# Altium schematic sheet DVK-AXM0F243-915-x-ANT-GEVB.SchDoc
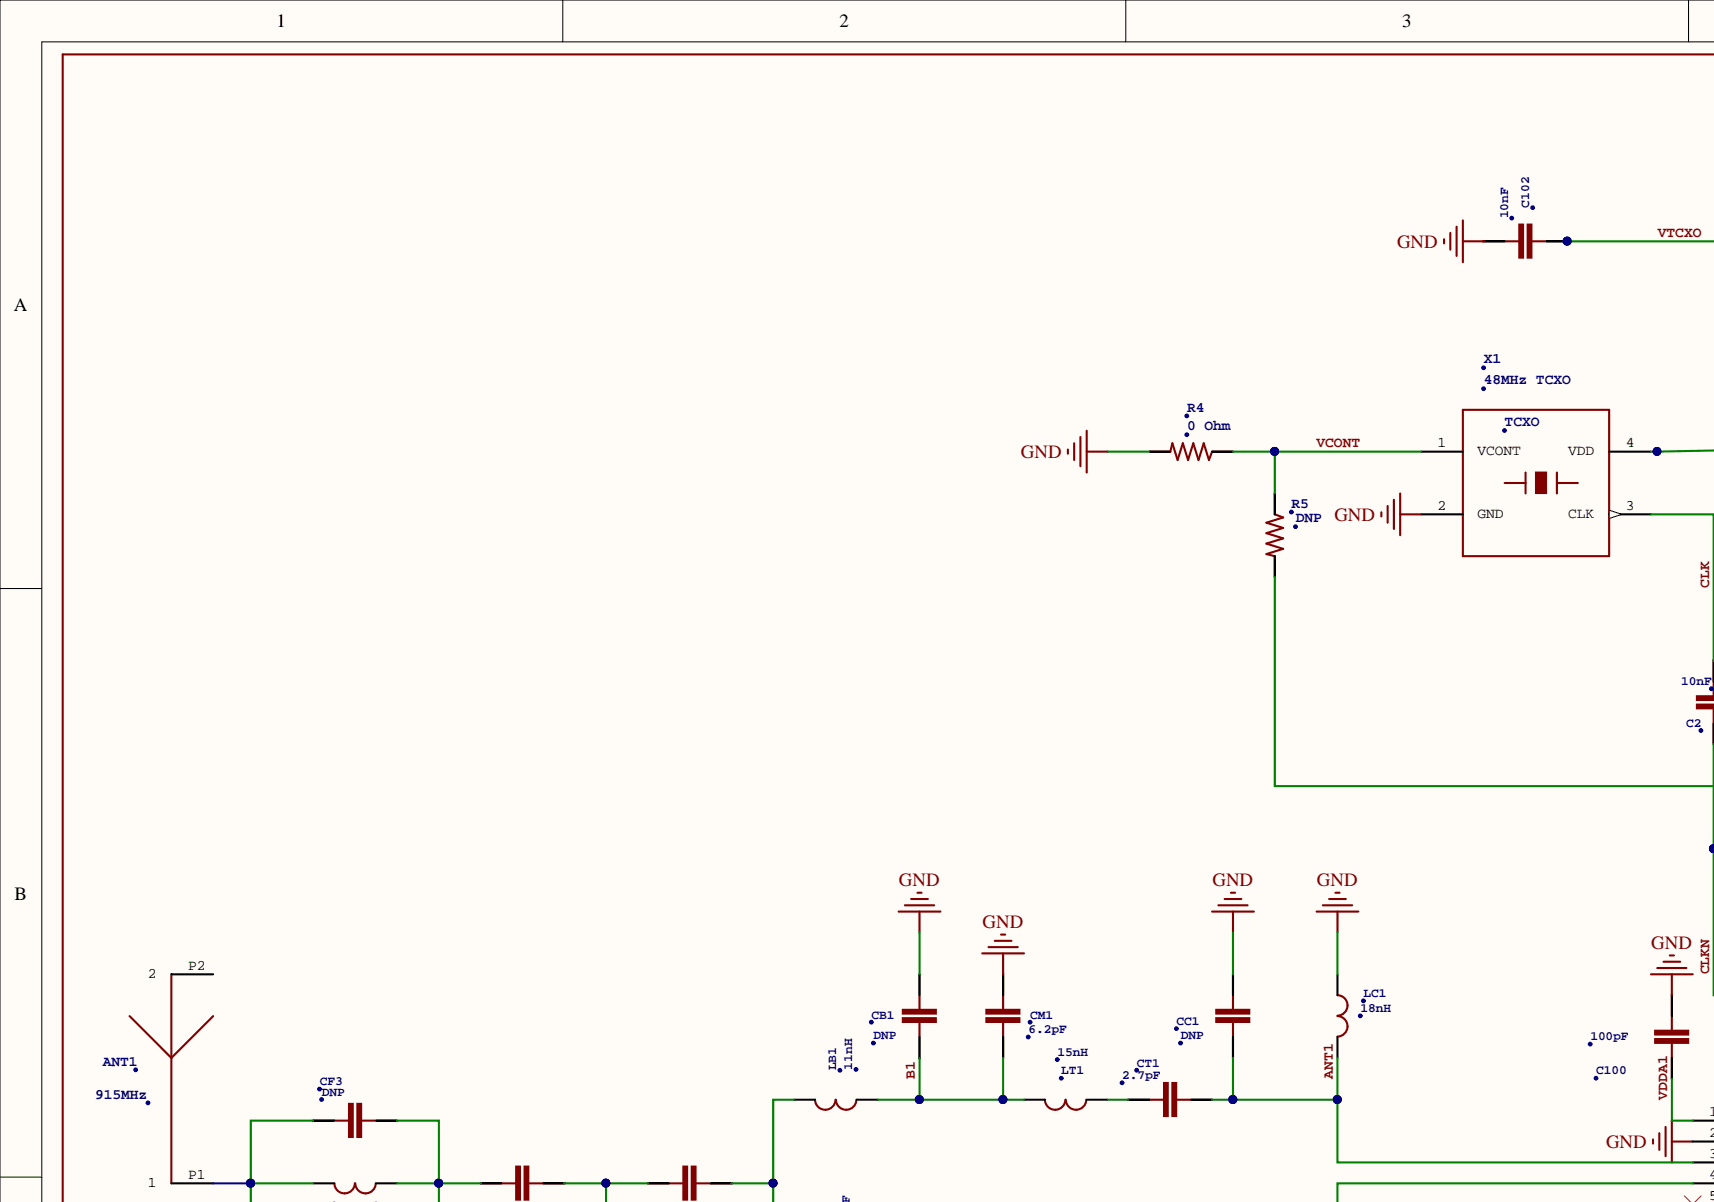
[diagram p0: DVK-AXM0F243-915-x-ANT-GEVB.SchDoc, top left]
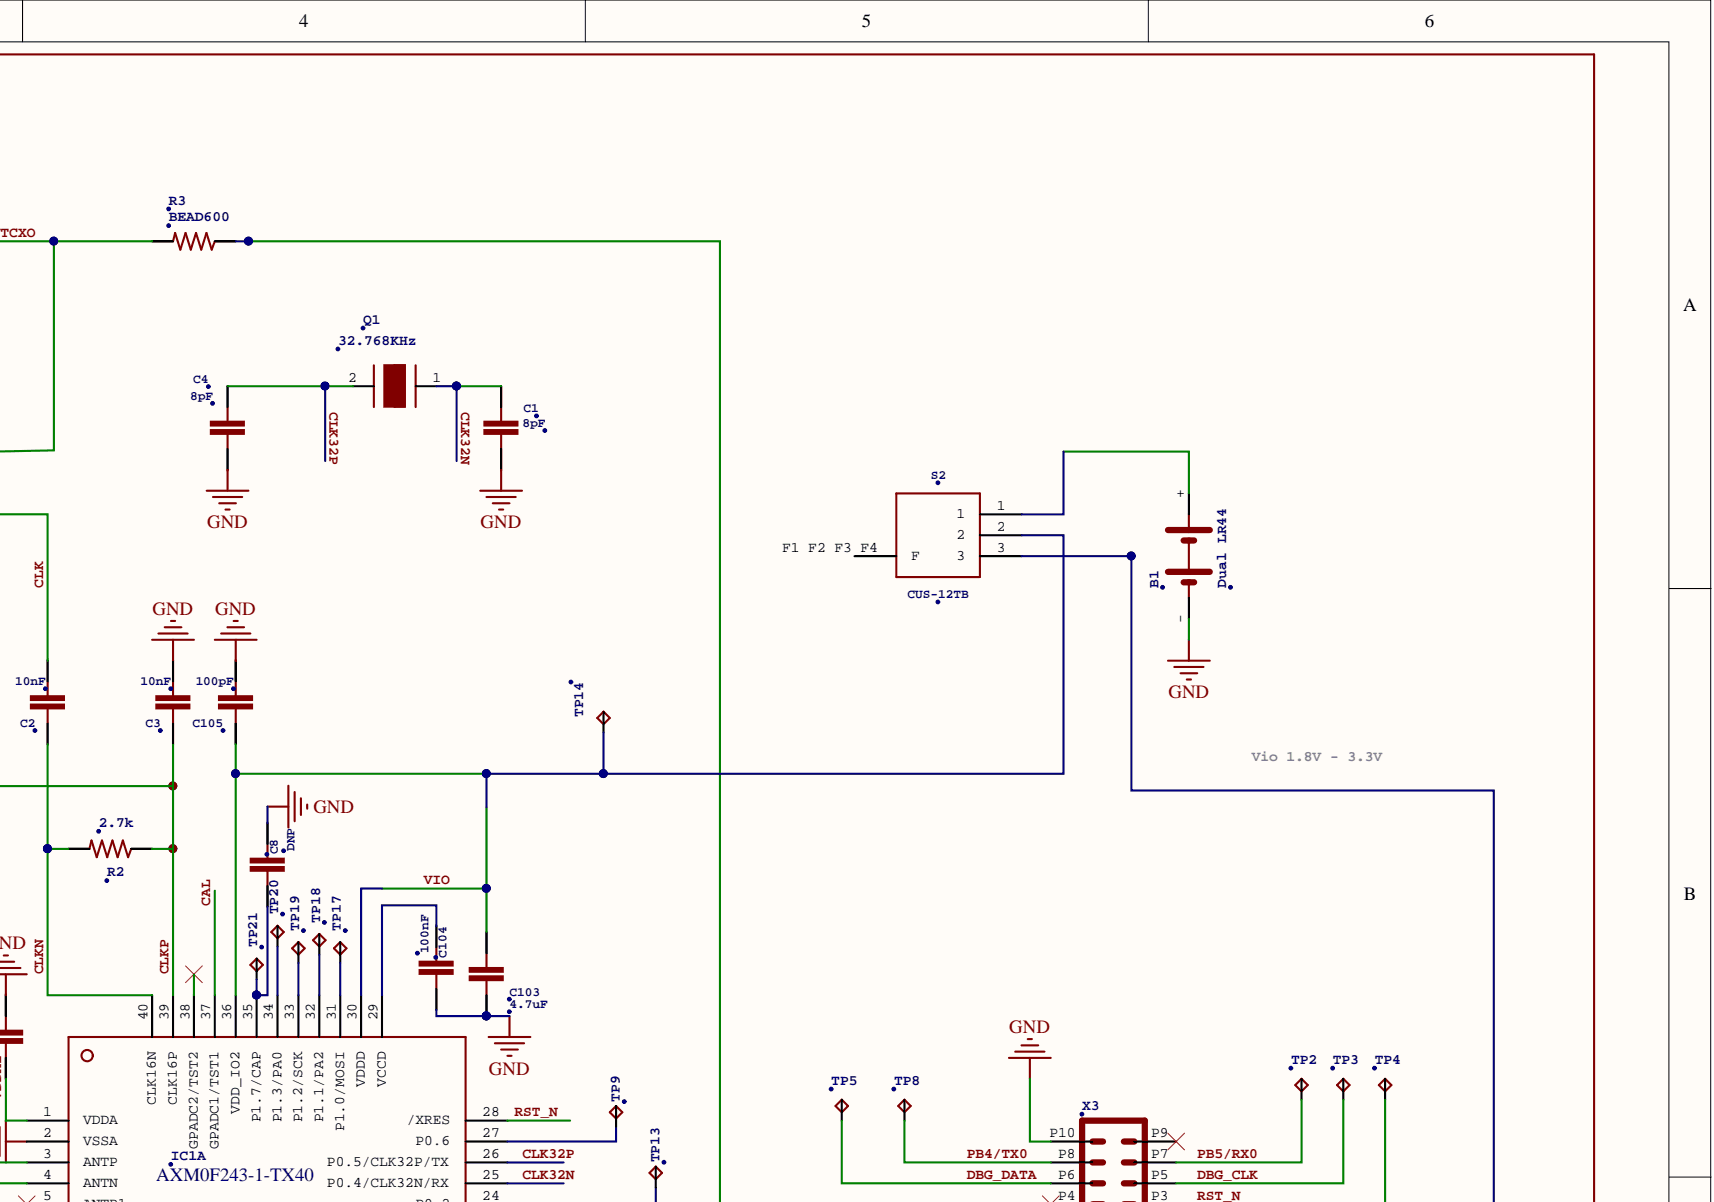
[diagram p1: DVK-AXM0F243-915-x-ANT-GEVB.SchDoc, top right]
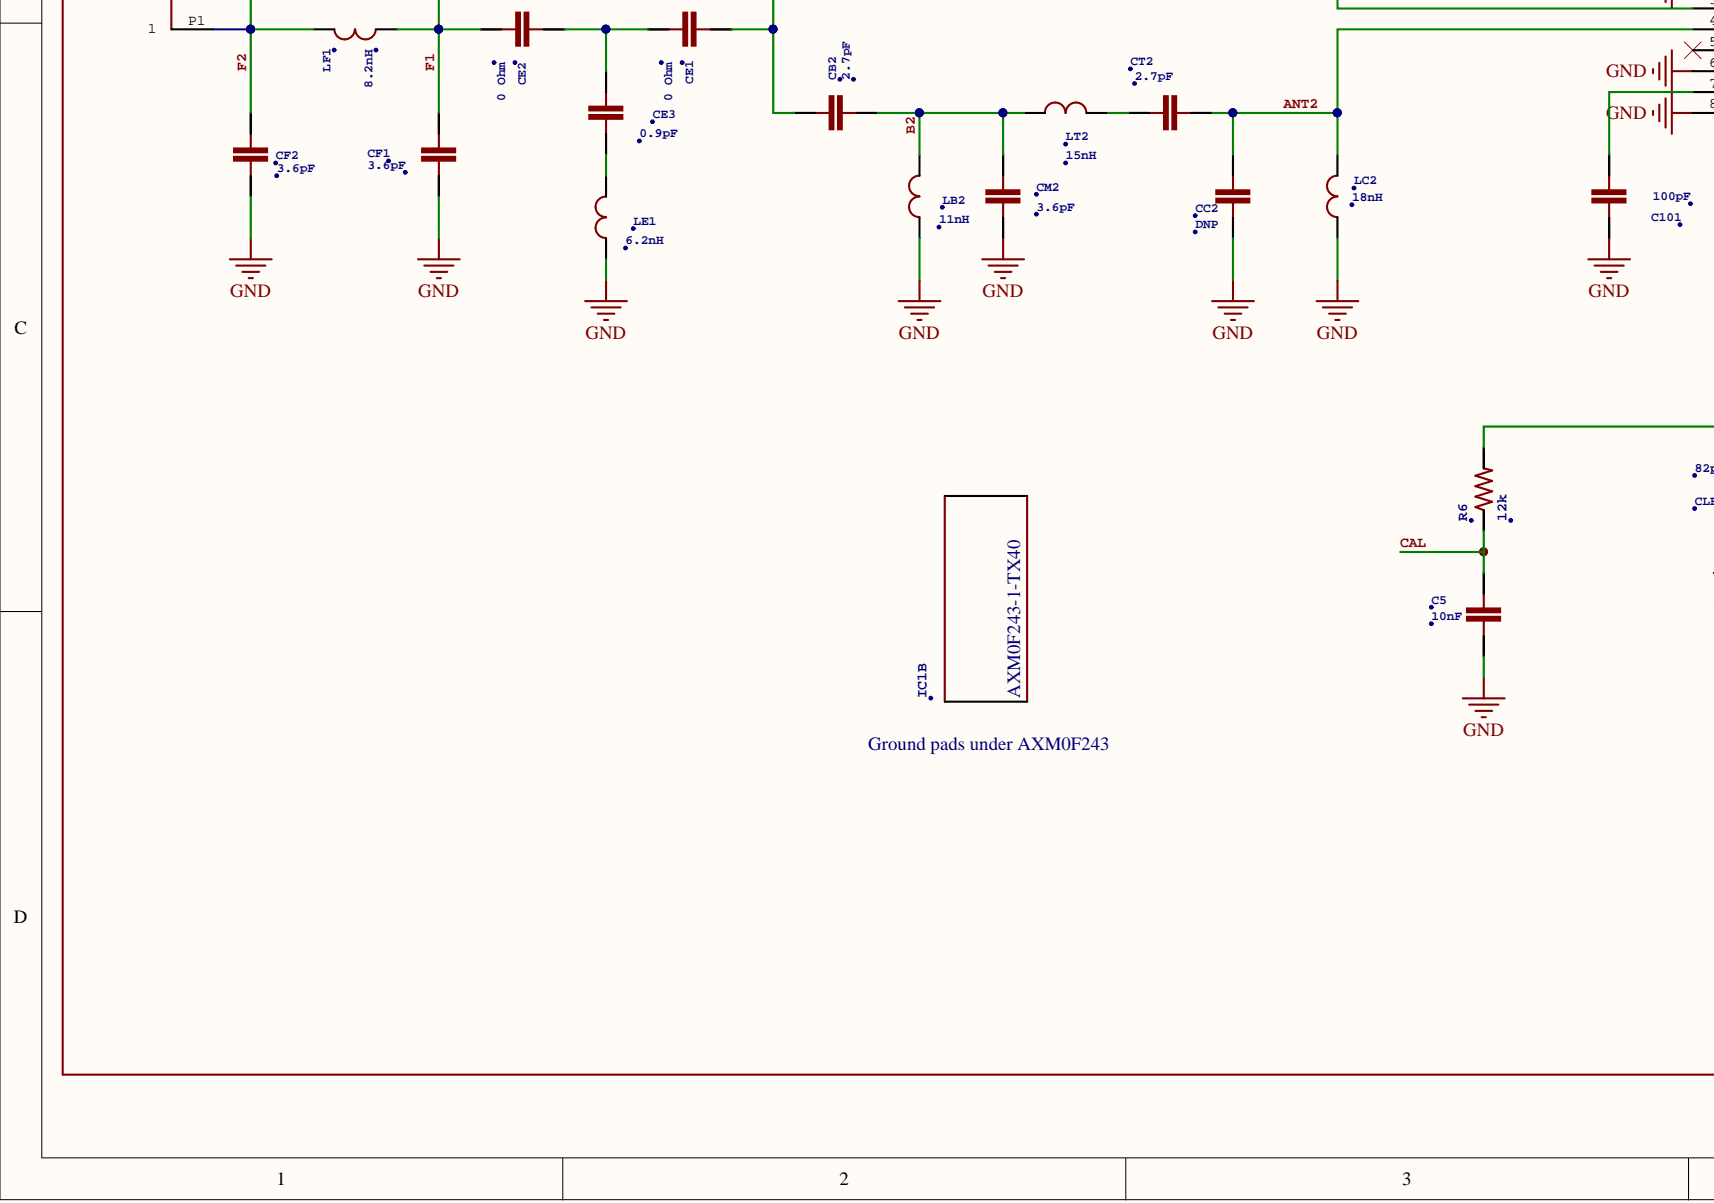
[diagram p2: DVK-AXM0F243-915-x-ANT-GEVB.SchDoc, bottom left]
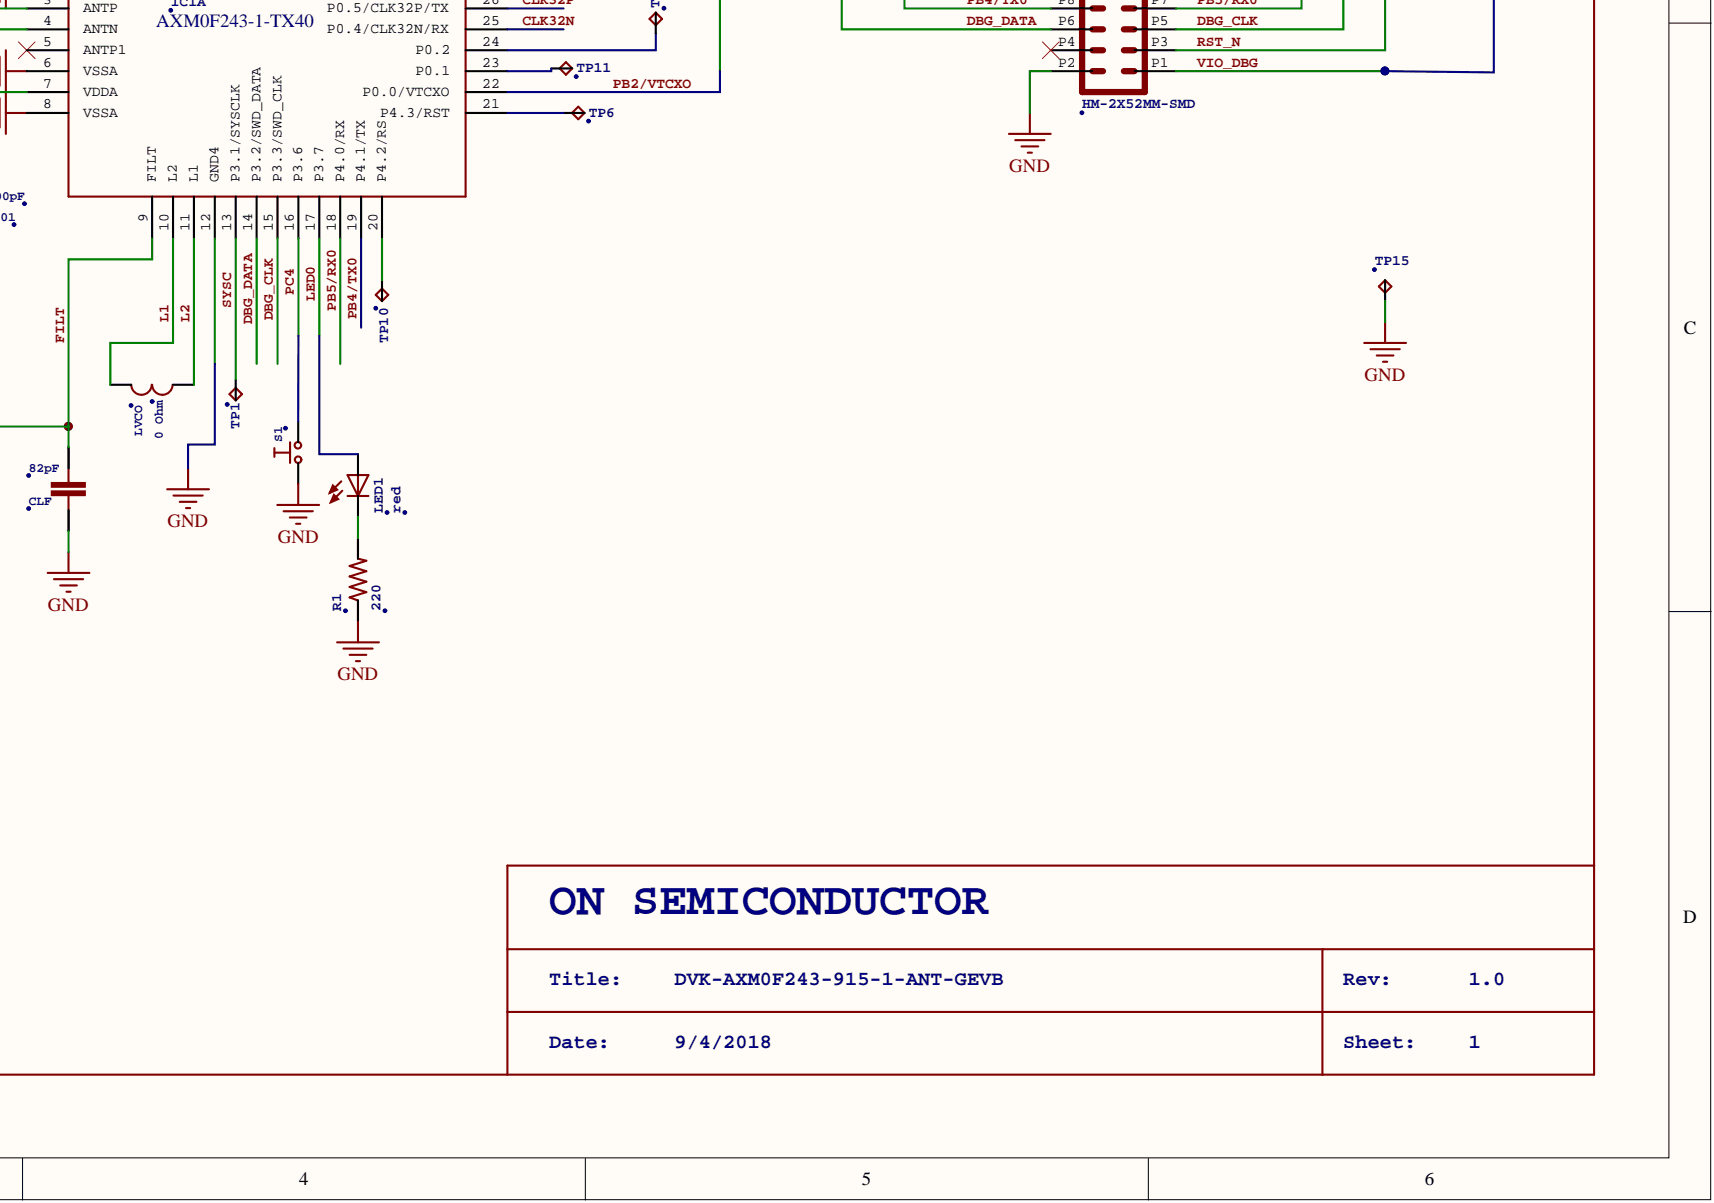
[diagram p3: DVK-AXM0F243-915-x-ANT-GEVB.SchDoc, bottom right]

## Parts
ANT1  915MHz  [YAGEO-CHIP]
B1  Dual LR44  [DUAL_LR44_VERT]
C1  8pF  [C0402]
C2  10nF  [C0402]
C3  10nF  [C0402]
C4  8pF  [C0402]
C5  10nF  [C0402]
C8  DNP  [C0402]
C100  100pF  [C0402]
C101  100pF  [C0402]
C102  10nF  [C0402]
C103  4.7uF  [C0603]
C104  100nF  [C0402]
C105  100pF  [C0402]
CB1  DNP  [C0402]
CB2  2.7pF  [C0402]
CC1  DNP  [C0402]
CC2  DNP  [C0402]
CE1  0 Ohm  [C0402]
CE2  0 Ohm  [C0402]
CE3  0.9pF  [C0402]
CF1  3.6pF  [C0402]
CF2  3.6pF  [C0402]
CF3  DNP  [C0402]
CLF  82pF  [C0402]
CM1  6.2pF  [C0402]
CM2  3.6pF  [C0402]
CT1  2.7pF  [C0402]
CT2  2.7pF  [C0402]
IC1  AXM0F243-1-TX40  [QFN40]  (part A)
IC1B  AXM0F243-1-TX40  [QFN40]
LB1  11nH  [L0402WW]
LB2  11nH  [L0402WW]
LC1  18nH  [L0402WW]
LC2  18nH  [L0402WW]
LE1  6.2nH  [L0402WW]
LED1  red  [LED0603]
LF1  8.2nH  [L0402WW]
LT1  15nH  [L0402WW]
LT2  15nH  [L0402WW]
LVCO  0 Ohm  [L0402WW]
Q1  32.768KHz  [TF20]
R1  220  [R0402]
R2  2.7k  [R0402]
R3  BEAD600  [R0402]
R4  0 Ohm  [R0402]
R5  DNP  [R0402]
R6  12k  [R0402]
S1  TASTER4-PAD-SMD-SMALL  [TASTER_SMALL_SMD_4PAD]  (value not drawn)
S2  CUS-12TB  [CUS-12TB]
TP1  PIN-03 - 1  [PIN-03 - 1]  (value not drawn)
TP2  TPTP10R  [TP14R]  (value not drawn)
TP3  TPTP10R  [TP14R]  (value not drawn)
TP4  TPTP10R  [TP14R]  (value not drawn)
TP5  TPTP10R  [TP14R]  (value not drawn)
TP6  PIN-03 - 1  [PIN-03 - 1]  (value not drawn)
TP8  TPTP10R  [TP14R]  (value not drawn)
TP9  PIN-03 - 1  [TP10R - 1]  (value not drawn)
TP10  PIN-03 - 1  [PIN-03 - 1]  (value not drawn)
TP11  PIN-03 - 1  [TP10R - 1]  (value not drawn)
TP13  PIN-03 - 1  [TP10R - 1]  (value not drawn)
TP14  TPTP10R  [TP14R]  (value not drawn)
TP15  TPTP10R  (value not drawn)
TP17  PIN-03 - 1  [PIN-03 - 1]  (value not drawn)
TP18  PIN-03 - 1  [PIN-03 - 1]  (value not drawn)
TP19  PIN-03 - 1  [PIN-03 - 1]  (value not drawn)
TP20  PIN-03 - 1  [PIN-03 - 1]  (value not drawn)
TP21  PIN-03 - 1  [PIN-03 - 1]  (value not drawn)
X1  48MHz TCXO  [X2016]
X3  HM-2X52MM-SMD  [HM-2MM-2X5-SMD]

## Nets
GND: B1.- C1.1 C3.1 C4.1 C5.2 C8.1 C100.1 C101.2 C102.1 C103.2 C104.2 C105.1 CB1.2 CC1.2 CC2.1 CF1.2 CF2.2 CLF.2 CM1.1 CM2.2 IC1.2(VSSA) IC1.6(VSSA) IC1.8(VSSA) IC1.12(GND4) IC1.41 IC1.42 IC1.43 IC1.44 IC1.45 IC1.46 IC1.47 IC1.48 IC1.49 IC1.50 IC1.51 IC1.52 IC1.53 IC1.54 IC1.55 LB2.1 LC1.1 LC2.1 LE1.1 R1.3 R4.4 S1.P3 TP15.TP X1.2(GND) X3.P2 X3.P10
ANT1: CC1.1 CT1.2 IC1.3(ANTP) LC1.2
ANT2: CC2.2 CT2.2 IC1.4(ANTN) LC2.2
B1: CB1.1 CM1.2 LB1.1 LT1.2
B2: CB2.2 CM2.1 LB2.2 LT2.1
CAL: C5.1 IC1.37(GPADC1/TST1) R6.3
CLK: C2.1 X1.3(CLK)
CLK32N: C1.2 IC1.25(P0.4/CLK32N/RX) Q1.1
CLK32P: C4.2 IC1.26(P0.5/CLK32P/TX) Q1.2
CLKN: C2.2 IC1.40(CLK16N) R2.4
CLKP: C3.2 IC1.39(CLK16P) R2.3 R5.4
DBG_CLK: IC1.15(P3.3/SWD_CLK) TP3.TP X3.P5
DBG_DATA: IC1.14(P3.2/SWD_DATA) TP5.TP X3.P6
F1: CE2.2 CF1.1 CF3.2 LF1.2
F2: ANT1.P1 CF2.1 CF3.1 LF1.1
FILT: CLF.1 IC1.9(FILT) R6.4
L1: IC1.10(L2) LVCO.2
L2: IC1.11(L1) LVCO.1
LED0: IC1.17(P3.7) LED1.A
PB2/VTCXO: IC1.22(P0.0/VTCXO) R3.3
PB4/TX0: IC1.19(P4.1/TX) TP8.TP X3.P8
PB5/RX0: IC1.18(P4.0/RX) TP2.TP X3.P7
PC4: IC1.16(P3.6) S1.P1
RST_N: IC1.28(/XRES) TP4.TP X3.P3
SYSC: IC1.13(P3.1/SYSCLK) TP1.P$1
VCONT: R4.3 R5.3 X1.1(VCONT)
VDDA1: C100.2 IC1.1(VDDA)
VIO: C103.1 C105.2 IC1.30(VDDD) IC1.36(VDD_IO2) S2.2 TP14.TP
VIO_DBG: S2.3 X3.P1
VTCXO: C102.2 R3.4 X1.4(VDD)
NetB1_+: B1.+ S2.1
NetC8_2: C8.2 IC1.35(P1.7/CAP) TP21.P$1
NetC101_1: C101.1 IC1.7(VDDA)
NetC104_1: C104.1 IC1.29(VCCD)
NetCB2_1: CB2.1 CE1.1 LB1.2
NetCE1_2: CE1.2 CE2.1 CE3.1
NetCE3_2: CE3.2 LE1.2
NetCT1_1: CT1.1 LT1.1
NetCT2_1: CT2.1 LT2.2
NetIC1_20: IC1.20(P4.2/RS) TP10.P$1
NetIC1_21: IC1.21(P4.3/RST) TP6.P$1
NetIC1_23: IC1.23(P0.1) TP11.P$1
NetIC1_24: IC1.24(P0.2) TP13.P$1
NetIC1_27: IC1.27(P0.6) TP9.P$1
NetIC1_31: IC1.31(P1.0/MOSI) TP17.P$1
NetIC1_32: IC1.32(P1.1/PA2) TP18.P$1
NetIC1_33: IC1.33(P1.2/SCK) TP19.P$1
NetIC1_34: IC1.34(P1.3/PA0) TP20.P$1
NetLED1_C: LED1.C R1.4

Not connected: ANT1 P2; IC1 5(ANTP1) 38(GPADC2/TST2); S2 F1 F2 F3 F4(F); X3 P4 P9

## Library data of the parts
ANT1 = YAGEO-CHIP868: Chip antenna; DeviceName: 868; GateName_1: G1; SymbolName_1: CHIP-ANTENNA-2-PIN; Component Kind: Standard; DeviceSetName: YAGEO-CHIP; Library Name: axsem_antenna_v1-2.IntLib; LibraryName: axsem_antenna_v1-2
B1 = DUAL_LR44_HOLDER: Dual LR44 HolderOrigin: China / aliexpress; GateName_1: G$1; SymbolName_1: BATT_DUAL; Component Kind: Standard; DeviceSetName: DUAL_LR44_HOLDER; Library Name: china_lr44_holder.IntLib; LibraryName: china_lr44_holder
C1-C5 C8 C100-C102 C104 C105 CB1 CB2 CC1 CC2 CE1-CE3 CF1-CF3 CLF CM1 CM2 CT1 CT2 = C0402: DeviceName: 0402; DeviceSetName: C; GateName_1: G$1; SymbolName_1: C-EU; Component Kind: Standard; Library Name: axsem_passives_v1-4.IntLib; LibraryName: axsem_passives_v1-4
C103 = C0603: DeviceName: 0603; DeviceSetName: C; GateName_1: G$1; SymbolName_1: C-EU; Component Kind: Standard; Library Name: axsem_passives_v1-4.IntLib; LibraryName: axsem_passives_v1-4
IC1 IC1B = AXM0F243_1: MCU 32-Bit AXM0F243 ARM Cortex M0+ RISC 64KB Flash 2.5V/3.3V 40-Pin QFN; DeviceName: N; DeviceSetName: SFEU; F243   Transceiver: AXM0F243   Transceiver PIN 41-55; GateName_1: IC; GateName_2: GP; SymbolName_1: AXSEMTRANSCEIVER; SymbolName_2: GROUNDPAD1; Component Kind: Standard; Library Name: AXM0F243-MINI-KIT-SMA_v2.0.SCHLIB; LibraryName: axsem_v4-26
LB1 LB2 LC1 LC2 LE1 LF1 LT1 LT2 LVCO = L0402WW: DeviceName: 0402WW; DeviceSetName: L; GateName_1: G$1; SymbolName_1: L-US; Component Kind: Standard; Library Name: axsem_passives_v1-7.IntLib; LibraryName: axsem_passives_v1-7
LED1 = LED0603: DeviceName: 0603; DeviceSetName: LED; GateName_1: G$1; Component Kind: Standard; SymbolName_1: LED; Library Name: axsem_passives_v1-4.IntLib; LibraryName: axsem_passives_v1-4
Q1 = XTAL-2PINTF20: GateName_1: Q; SymbolName_1: XTAL; Component Kind: Standard; DeviceName: TF20; DeviceSetName: XTAL-2PIN; Library Name: axsem_osc_v1-2.IntLib; LibraryName: axsem_osc_v1-2
R1-R6 = R0402: DeviceName: 0402; DeviceSetName: R; GateName_1: G$1; SymbolName_1: R-US; Component Kind: Standard; Library Name: axsem_passives_v1-4.IntLib; LibraryName: axsem_passives_v1-4
S1 = TASTER4-PAD-SMD-SMALL: AXSEM tasters; DeviceSetName: TASTER; GateName_1: G$1; Component Kind: Standard; DeviceName: 4-PAD-SMD-SMALL; Library Name: axsem_switches_v1.2.IntLib; LibraryName: axsem_switches_v1.2; SymbolName_1: TASTER
S2 = CUS-12TB: 563-1102-1-ND; GateName_1: G$1; Component Kind: Standard; DeviceSetName: CUS-12TB; Library Name: slide_switch.IntLib; LibraryName: slide_switch; SymbolName_1: CUS-12TB
TP1 TP6 TP9-TP11 TP13 TP17-TP21 = TP03: Test pad; DeviceName: 03; DeviceSetName: TP; Component Kind: Standard; GateName_1: TP; SymbolName_1: TP; Library Name: axsem_testpad_v1-0.IntLib; LibraryName: axsem_testpad_v1-0
TP2-TP5 TP8 TP14 TP15 = TPTP10R: Test pad; DeviceName: TP10R; DeviceSetName: TP; GateName_1: G$1; TP_SIGNAL_NAME: >TP_SIGNAL_NAME; Component Kind: Standard; Library Name: AXM0F243-MINI-KIT-SMA_v1.0.SCHLIB; SymbolName_1: TP; LibraryName: testpad
X1 = TCXO: GateName_1: G$1; Component Kind: Standard; DeviceSetName: TCXO; SymbolName_1: TCXO; TCXO: TCXO; Library Name: axsem_osc_v1-3.IntLib; LibraryName: axsem_osc_v1-3
X3 = HM-2X52MM-SMD: DeviceSetName: HM-2X5; GateName_1: H1; Component Kind: Standard; DeviceName: 2MM-SMD; SymbolName_1: HM-2X5; Library Name: axsem_connectors_v1-5.IntLib; LibraryName: axsem_connectors_v1-5
